# Altium schematic sheet Thermistor and LED Circuit.SchDoc
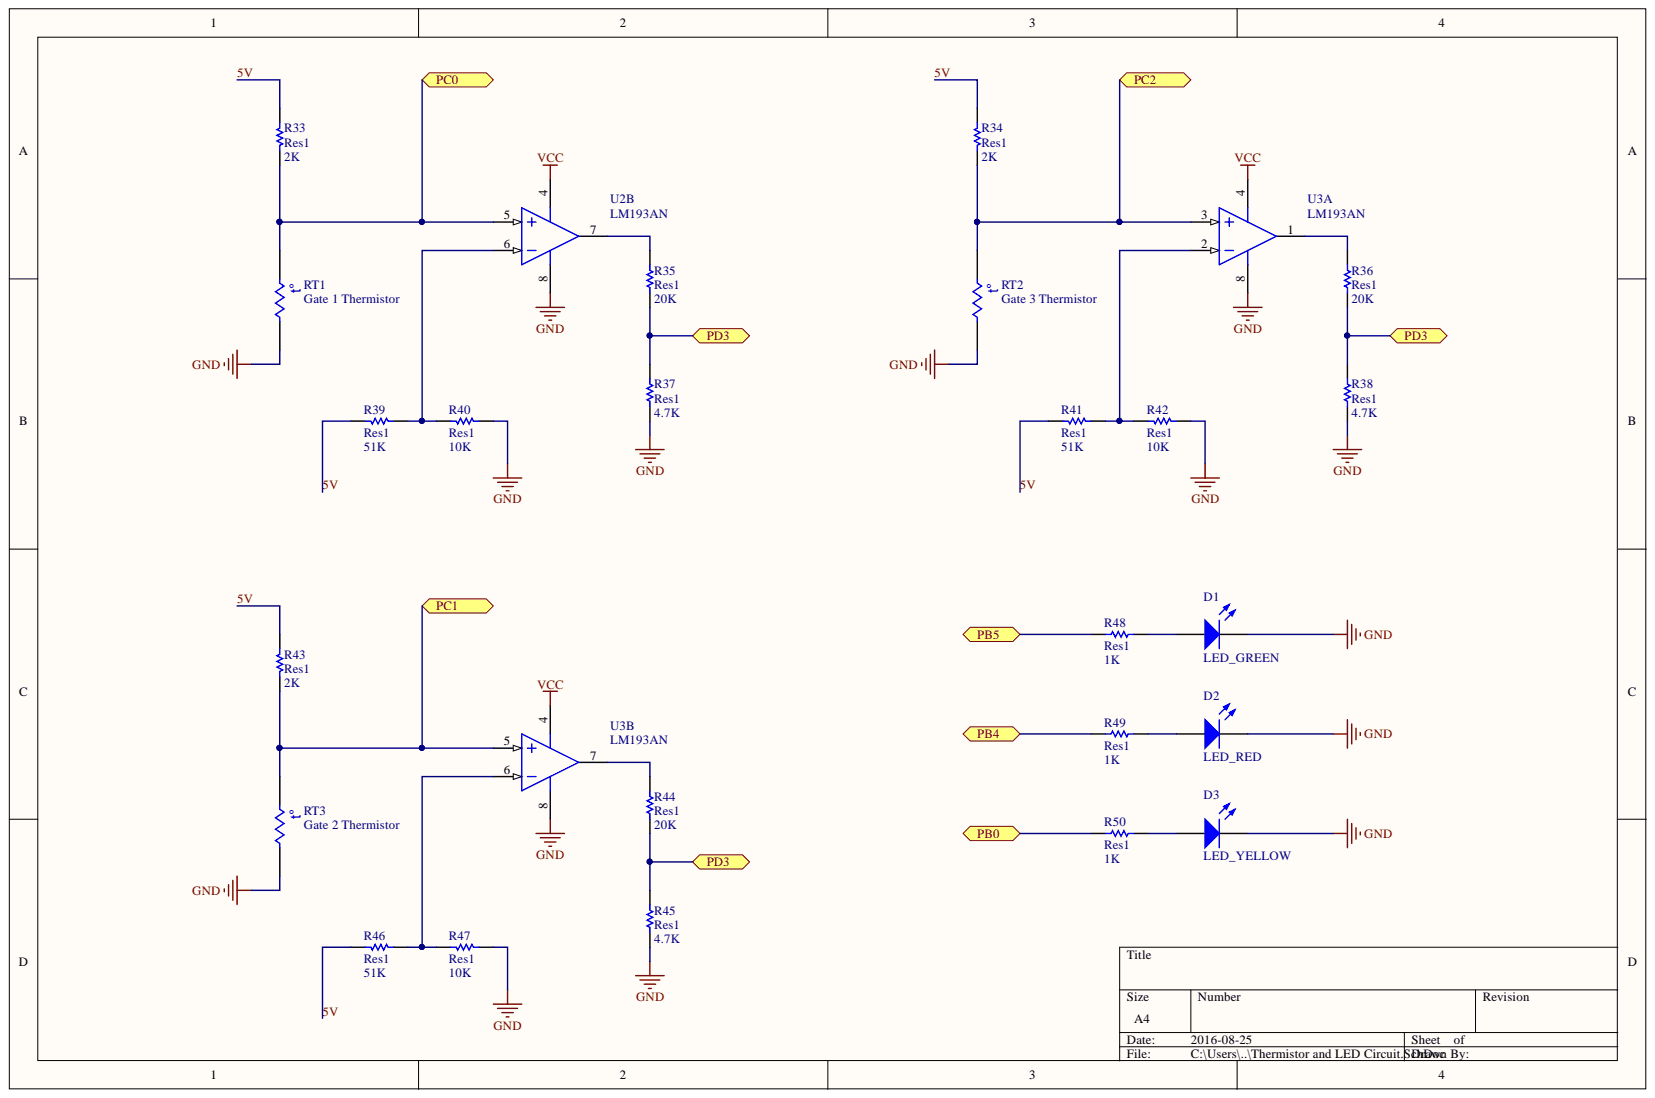
[diagram p0: Thermistor and LED Circuit.SchDoc]

## Parts
D1  LED_GREEN  [LED-0]
D2  LED_RED  [LED-0]
D3  LED_YELLOW  [LED-0]
R33  Res1  value 2K  [AXIAL-0.3]
R34  Res1  value 2K  [AXIAL-0.3]
R35  Res1  value 20K  [AXIAL-0.3]
R36  Res1  value 20K  [AXIAL-0.3]
R37  Res1  value 4.7K  [AXIAL-0.3]
R38  Res1  value 4.7K  [AXIAL-0.3]
R39  Res1  value 51K  [AXIAL-0.3]
R40  Res1  value 10K  [AXIAL-0.3]
R41  Res1  value 51K  [AXIAL-0.3]
R42  Res1  value 10K  [AXIAL-0.3]
R43  Res1  value 2K  [AXIAL-0.3]
R44  Res1  value 20K  [AXIAL-0.3]
R45  Res1  value 4.7K  [AXIAL-0.3]
R46  Res1  value 51K  [AXIAL-0.3]
R47  Res1  value 10K  [AXIAL-0.3]
R48  Res1  value 1K  [AXIAL-0.3]
R49  Res1  value 1K  [AXIAL-0.3]
R50  Res1  value 1K  [AXIAL-0.3]
RT1  Gate 1 Thermistor  [6-0805_N]
RT2  Gate 3 Thermistor  [6-0805_N]
RT3  Gate 2 Thermistor  [6-0805_N]
U2  LM193AN  [DIP8]  (part B)
U3  LM193AN  [DIP8]  (parts A,B)

## Ports
PB0, PB4, PB5, PC0, PC1, PC2, PD3

## Nets
GND: D1.2 D2.2 D3.2 R37.1 R38.1 R40.1 R42.1 R45.1 R47.1 RT1.2 RT2.2 RT3.2 U2.8 U3.8
VCC: U2.4 U3.4
5V: R33.2 R34.2 R39.2 R41.2 R43.2 R46.2
PB0: R50.1 ; port PB0
PB4: R49.1 ; port PB4
PB5: R48.1 ; port PB5
PC0: R33.1 RT1.1 U2.5 ; port PC0
PC1: R43.1 RT3.1 U3.5 ; port PC1
PC2: R34.1 RT2.1 U3.3 ; port PC2
PD3: R35.1 R36.1 R37.2 R38.2 R44.1 R45.2 ; port PD3
NetD1_1: D1.1 R48.2
NetD2_1: D2.1 R49.2
NetD3_1: D3.1 R50.2
NetR35_2: R35.2 U2.7
NetR36_2: R36.2 U3.1
NetR39_1: R39.1 R40.2 U2.6
NetR41_1: R41.1 R42.2 U3.2
NetR44_2: R44.2 U3.7
NetR46_1: R46.1 R47.2 U3.6

## Library data of the parts
D1-D3 = LED0: Typical INFRARED GaAs LED; Component Kind: Standard; LatestRevisionDate: 08-Jul-2005; LatestRevisionNote: Mech Layer 1 removed.; Library Name: Miscellaneous Devices.IntLib; PackageDescription: LED; 2 Leads; PackageReference: LED-0; Published: 8-Jun-2000; Simulation: LED0; Publisher: Altium Limited
R33-R50 = Res1: Resistor; Component Kind: Standard; LatestRevisionDate: 17-Jul-2002; LatestRevisionNote: Re-released for DXP Platform.; Library Name: Miscellaneous Devices.IntLib; PackageDescription: Axial Device, Thru-Hole; 2 Leads; 0.3 in Pin Spacing; PackageReference: AXIAL-0.3; Published: 8-Jun-2000; Signal Integrity: Res; Simulation: RESISTOR; Publisher: Altium Limited
RT1-RT3 = Res Thermal: Thermistor; Code_IPC: RESC2012; Component Kind: Standard; LatestRevisionDate: 29-May-2009; LatestRevisionNote: IPC-7351 Footprint Added.; Library Name: Miscellaneous Devices.IntLib; PackageDescription: Chip Resistor 0805 Case Size; PackageReference: 6-0805; PackageVersion: Mar-2003; Published: 24-Mar-1999; Publisher: Altium Limited
U2 U3 = LM193AN: Low-Power Dual Voltage Comparator; Code_JEDEC: MO-001-AN; Component Kind: Standard; ComponentLink1Description: Manufacturer Link; ComponentLink1URL: http://www.st.com/; ComponentLink2Description: Datasheet; ComponentLink2URL: http://www.st.com/stonline/products/literature/ds/2164/lm193.pdf; DatasheetVersion: Nov-1992; LatestRevisionDate: 06-Jun-2005; LatestRevisionNote: Stylized 3D Model Added.; Library Name: ST Analog Comparator.IntLib; PackageDescription: DIP; 8 Leads; Row Spacing 7.62 mm; Pitch 2.54 mm; PackageReference: DIP8; PCB3D: DIP8; Published: 24-Aug-2001; Publisher: Altium Limited
